# Altium schematic sheet MP_DP_Interface.SchDoc(MP_DP_Interface)
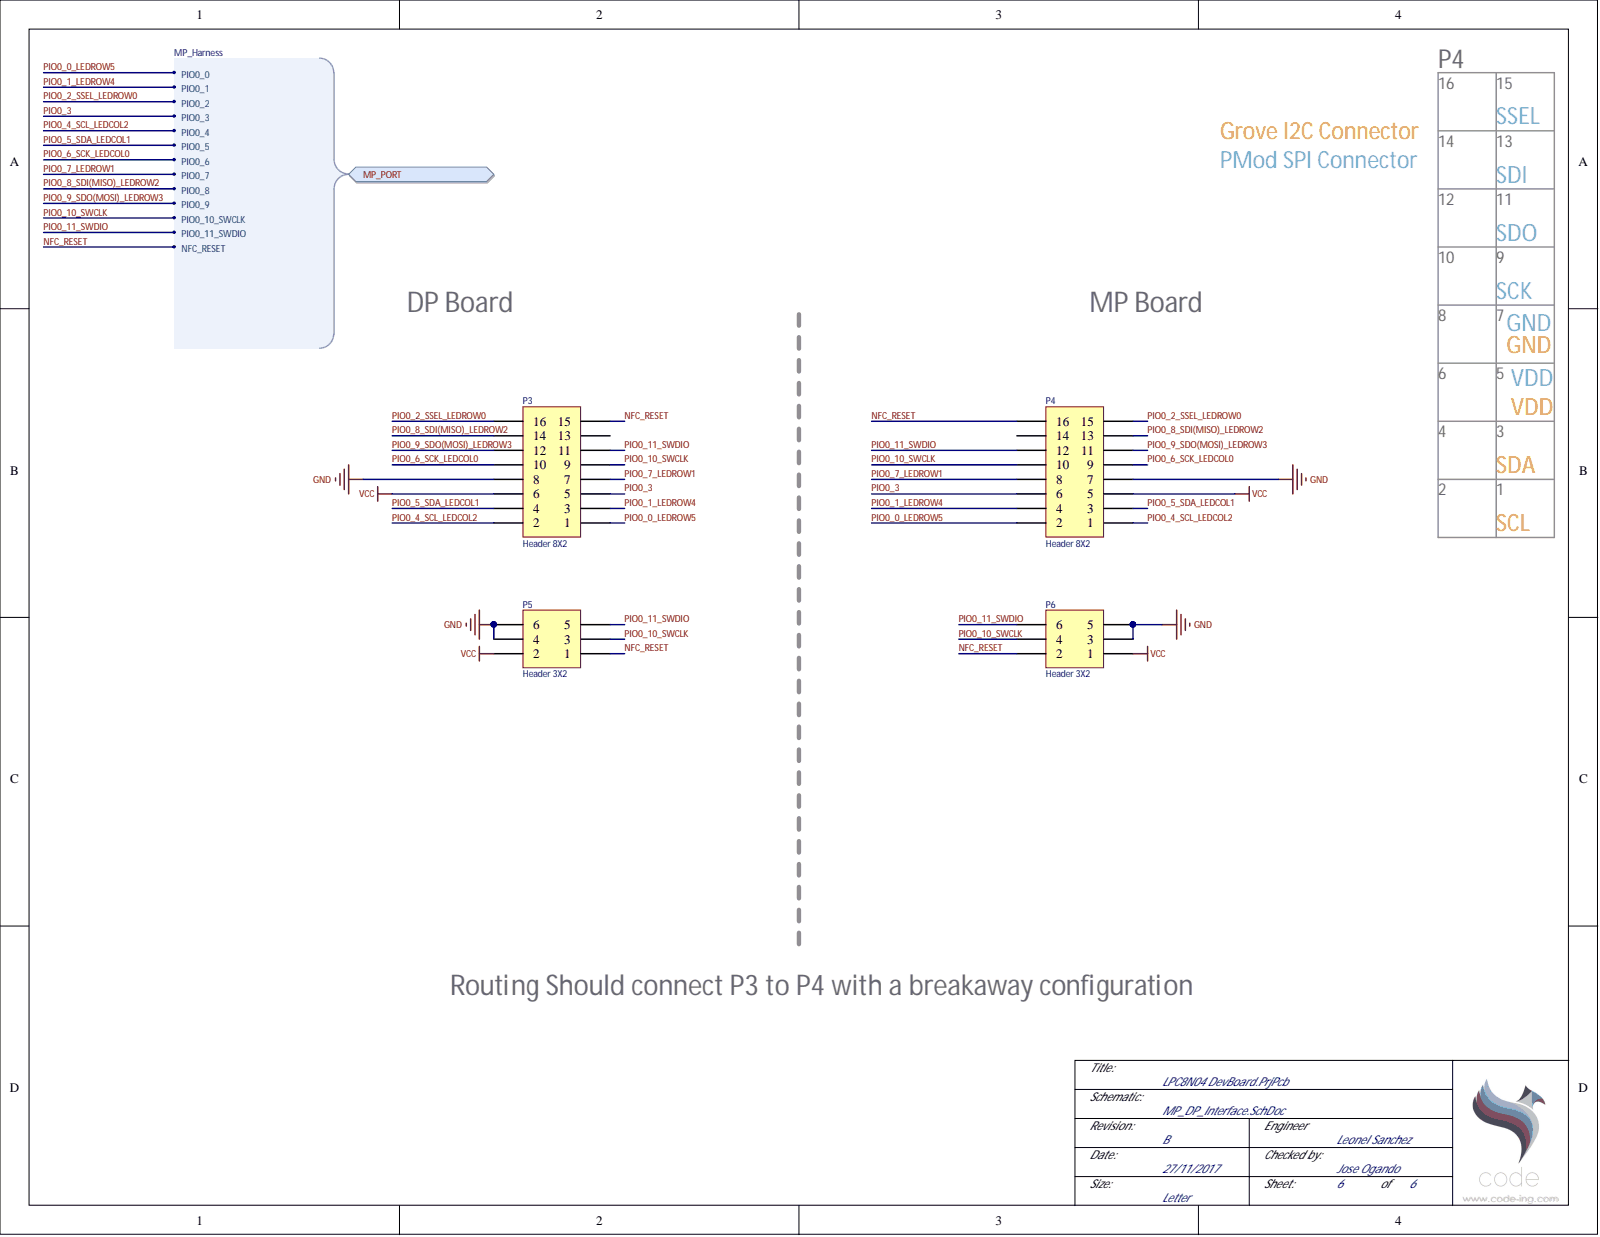
[diagram p0: MP_DP_Interface.SchDoc]

## Parts
P3  Header 8X2  [HDR2X8]
P4  Header 8X2  [HDR2X8]
P5  Header 3X2  [HDR2X3]
P6  Header 3X2  [HDR2X3]

## Ports
MP_PORT, MP_PORT.NFC_RESET, MP_PORT.PIO0_0, MP_PORT.PIO0_1, MP_PORT.PIO0_2, MP_PORT.PIO0_3, MP_PORT.PIO0_4, MP_PORT.PIO0_5, MP_PORT.PIO0_6, MP_PORT.PIO0_7, MP_PORT.PIO0_8, MP_PORT.PIO0_9, MP_PORT.PIO0_10_SWCLK, MP_PORT.PIO0_11_SWDIO

## Nets
GND: P3.8 P4.7 P5.4 P5.6 P6.3 P6.5
VCC: P3.6 P4.5 P5.2 P6.1
NFC_RESET: P3.15 P4.16 P5.1 P6.2 ; port MP_PORT
PIO0_0_LEDROW5: P3.1 P4.2 ; port MP_PORT
PIO0_1_LEDROW4: P3.3 P4.4 ; port MP_PORT
PIO0_2_SSEL_LEDROW0: P3.16 P4.15 ; port MP_PORT
PIO0_3: P3.5 P4.6 ; port MP_PORT
PIO0_4_SCL_LEDCOL2: P3.2 P4.1 ; port MP_PORT
PIO0_5_SDA_LEDCOL1: P3.4 P4.3 ; port MP_PORT
PIO0_6_SCK_LEDCOL0: P3.10 P4.9 ; port MP_PORT
PIO0_7_LEDROW1: P3.7 P4.8 ; port MP_PORT
PIO0_8_SDI(MISO)_LEDROW2: P3.14 P4.13 ; port MP_PORT
PIO0_9_SDO(MOSI)_LEDROW3: P3.12 P4.11 ; port MP_PORT
PIO0_10_SWCLK: P3.9 P4.10 P5.3 P6.4 ; port MP_PORT
PIO0_11_SWDIO: P3.11 P4.12 P5.5 P6.6 ; port MP_PORT

Not connected: P3 13; P4 14

## Library data of the parts
P3 P4 = Header 8X2: Header, 8-Pin, Dual row; Signal Integrity: Connector; Library Name: Miscellaneous Connectors.IntLib; LatestRevisionNote: Re-released for DXP Platform.; LatestRevisionDate: 17-Jul-2002; Component Kind: Standard; Publisher: Altium Limited
P5 P6 = Header 3X2: Header, 3-Pin, Dual row; Signal Integrity: Connector; Library Name: Miscellaneous Connectors.IntLib; LatestRevisionNote: Re-released for DXP Platform.; LatestRevisionDate: 17-Jul-2002; Component Kind: Standard; Publisher: Altium Limited
